# Altium schematic sheet CanNode.SchDoc(CanNode)
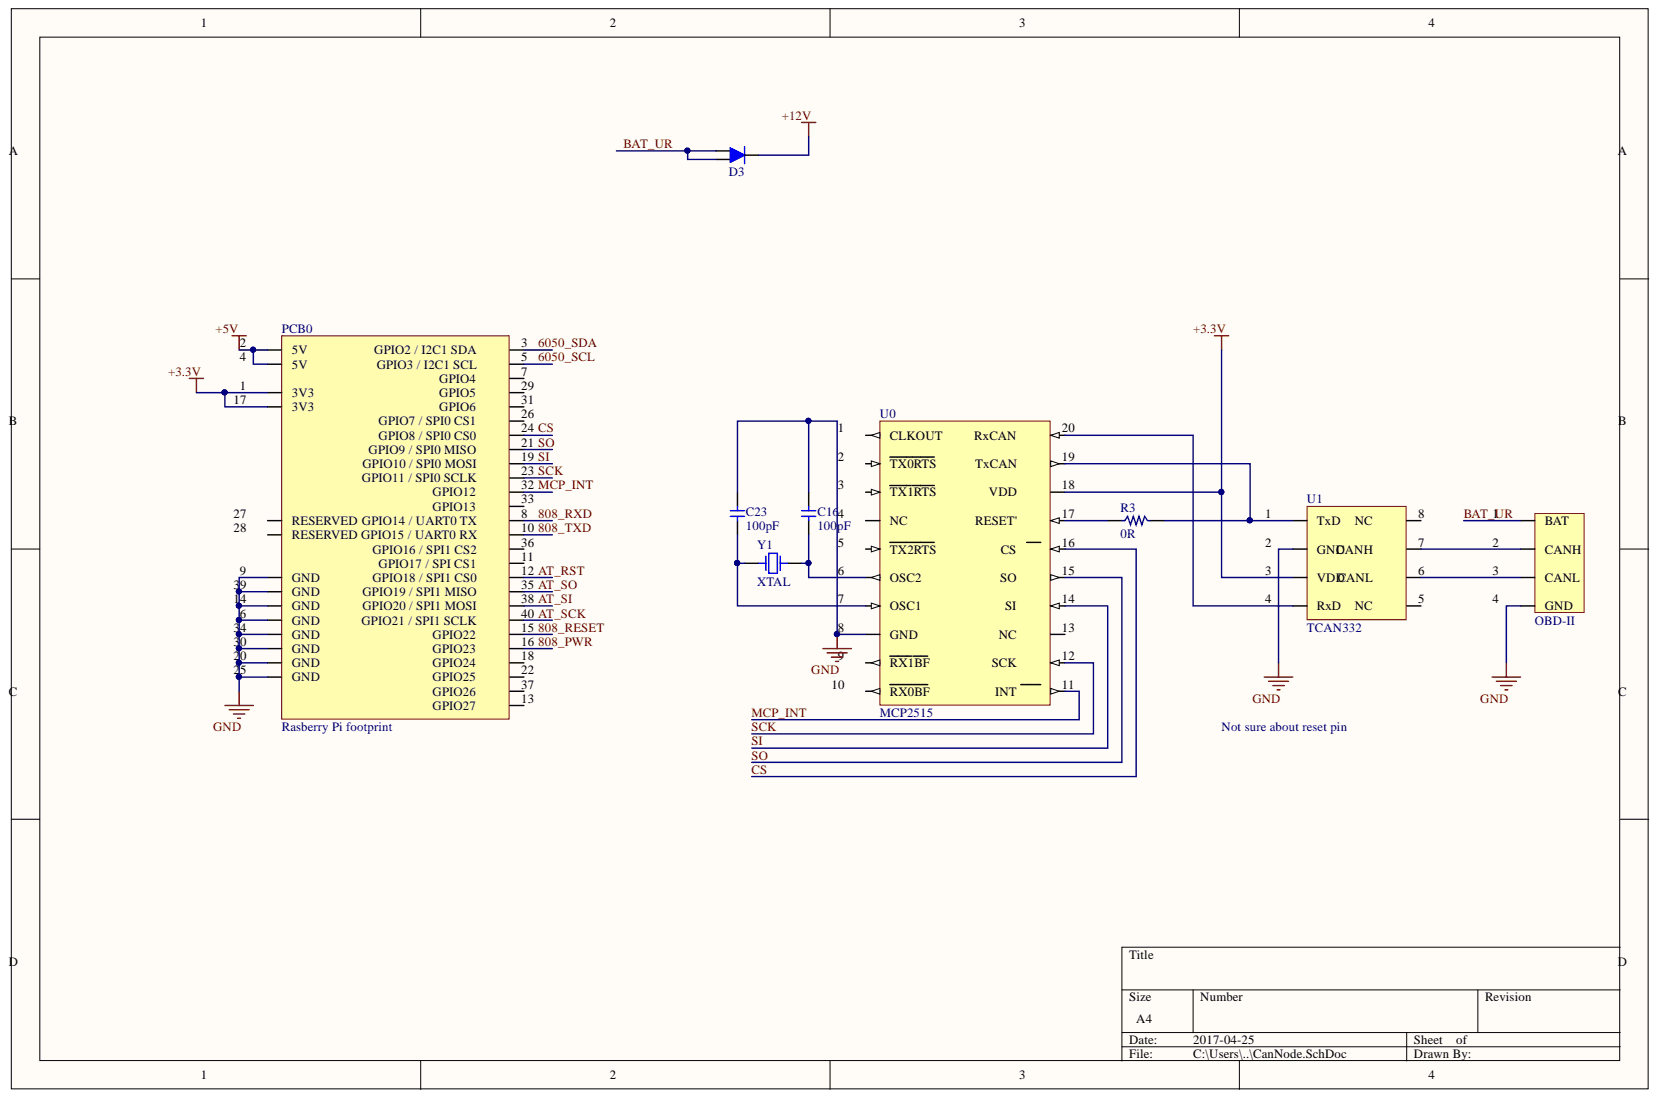
[diagram p0: CanNode.SchDoc]

## Parts
C16  value 100pF  [0805]  (hidden comment: Generic Capacitor)
C23  value 100pF  [0805]  (hidden comment: Generic Capacitor)
D3  DIODE SCHOTTKY 60V 5A  [TO277A]  (value not drawn)
OBD-II  OBD-II  [OBD_II]
PCB0  Rasberry Pi footprint  [Rasberry PI]
R3  value 0R  [0805]  (hidden comment: Resistor)
U0  MCP2515  [MCP2515]
U1  TCAN332  [SOIC-8]
Y1  XTAL  [XTAL-16.000]

## Nets
+3.3V: PCB0.1(3V3) PCB0.17(3V3) U0.18 U1.3(VDD)
+5V: PCB0.2(5V) PCB0.4(5V)
+12V: D3.3
GND: C16.2 C23.2 OBD-II.4(GND) PCB0.6(GND) PCB0.9(GND) PCB0.14(GND) PCB0.20(GND) PCB0.25(GND) PCB0.30(GND) PCB0.34(GND) PCB0.39(GND) U0.8(GND) U1.2(GND)
808_PWR: PCB0.16
808_RESET: PCB0.15
808_RXD: PCB0.8
808_TXD: PCB0.10
6050_SCL: PCB0.5
6050_SDA: PCB0.3
AT_RST: PCB0.12
AT_SCK: PCB0.40
AT_SI: PCB0.38
AT_SO: PCB0.35
BAT_UR: D3.1 D3.2 OBD-II.1(BAT)
CS: PCB0.24 U0.16
MCP_INT: PCB0.32 U0.11
SCK: PCB0.23 U0.12
SI: PCB0.19 U0.14
SO: PCB0.21 U0.15
NetC16_1: C16.1 U0.6(OSC2) Y1.1
NetC23_1: C23.1 U0.7(OSC1) Y1.0
NetOBD-II_2: OBD-II.2(CANH) U1.7
NetOBD-II_3: OBD-II.3(CANL) U1.6
NetR3_1: R3.1 U0.17
NetR3_2: R3.2 U0.19 U1.1(TxD)
NetU0_20: U0.20 U1.4(RxD)

Not connected: PCB0 7 11 13 18 22 26 27 28 29 31 33 36 37; U0 1(CLKOUT) 2(TX0RTS) 3(TX1RTS) 4(NC) 5(TX2RTS) 9(RX1BF) 10(RX0BF) 13; U1 5 8

## Library data of the parts
C16 C23 = Capacitor: Component Kind: Standard; Description: Generic capacitor; Library Name: PowerCircuit.SchLib
D3 = SS5P6-M3/86A: DIODE SCHOTTKY 60V 5A TO277A; Manufacturer: Vishay Semiconductor Diodes Division; Capacitance @ Vr, F: 200pF @ 4V, 1MHz; Current - Reverse Leakage @ Vr: 150µA @ 60V; Speed: Fast Recovery =< 500ns, > 200mA (Io); Voltage - Forward (Vf) (Max) @ If: 690mV @ 5A; Component Kind: Standard; ComponentLink1Description: http://www.vishay.com/docs/88988/ss5p6.pdf; ComponentLink1URL: http://www.vishay.com/docs/88988/ss5p6.pdf; Current - Average Rectified (Io): 5A; Diode Type: Schottky; Library Name: PowerCircuit.SchLib; Manufacturer Part Number: SS5P6-M3/86A; Mounting Type: Surface Mount; Operating Temperature - Junction: -55°C ~ 150°C; Package / Case: TO-277, 3-PowerDFN; Packaging: Cut Tape (CT); Part Status: Active; Pricing 1: 1=0.92, 10=0.795, 25=0.7424, 100=0.5937, 250=0.55132, 500=0.4665 (CAD); Reverse Recovery Time (trr): -; Supplier 1: Digi-Key; Supplier Device Package: TO-277A (SMPC); Supplier Part Number 1: SS5P6-M3/86AGICT-ND; Voltage - DC Reverse (Vr) (Max): 60V
PCB0 = Rasberry Pi: Component Kind: Standard; Description: Rasberry Pi footprint; Library Name: CanNode.SchLib
R3 = Resistor: Generic Resistor; Component Kind: Standard; Library Name: PowerCircuit.SchLib
U0 = MCP2515: IC CAN CTLR W/SPI 2.0B 20-QFN; Mfr 1: Microchip Technology; Notes: Not sure about reset pin; Component Kind: Standard; Digi-Key Part Number: MCP2515T-I/MLCT-ND; Library Name: CanNode.SchLib
U1 = TCAN332: IC TXRX CAN 8SOIC; Mfr 1: Texas Instruments; Component Kind: Standard; Digi-Key Part Number: 296-43711-1-ND; Library Name: CanNode.SchLib
Y1 = XTAL-16.000: XTAL16.0000MHZ 18PF SMD; Manufacturer: Abracon LLC; Manufacturer Part Number: ABM7-16.000MHZ-D2Y-T; ESR (Equivalent Series Resistance): 50 Ohm; Frequency: 16MHz; Frequency Stability: ±30ppm; Frequency Tolerance: ±20ppm; Operating Mode: Fundamental; Component Kind: Standard; ComponentLink1Description: http://www.abracon.com/Resonators/abm7.pdf; ComponentLink1URL: http://www.abracon.com/Resonators/abm7.pdf; Height - Seated (Max): 0.055" (1.40mm); Library Name: CanNode.SchLib; Load Capacitance: 18pF; Mounting Type: Surface Mount; Operating Temperature: -40°C ~ 85°C; Package / Case: 2-SMD, No Lead; Packaging: Cut Tape (CT); Part Status: Active; Pricing 1: 1=1.12, 10=0.989, 50=0.9234, 100=0.8162, 500=0.77322 (CAD); Ratings: -; Size / Dimension: 0.236" L x 0.138" W (6.00mm x 3.50mm); Supplier 1: Digi-Key; Supplier Part Number 1: 535-9840-1-ND; Type: MHz Crystal
OBD-II = OBD-II: Component Kind: Standard; Description: OBD-II; Library Name: CanNode.SchLib
